# Altium schematic sheet Power.SchDoc
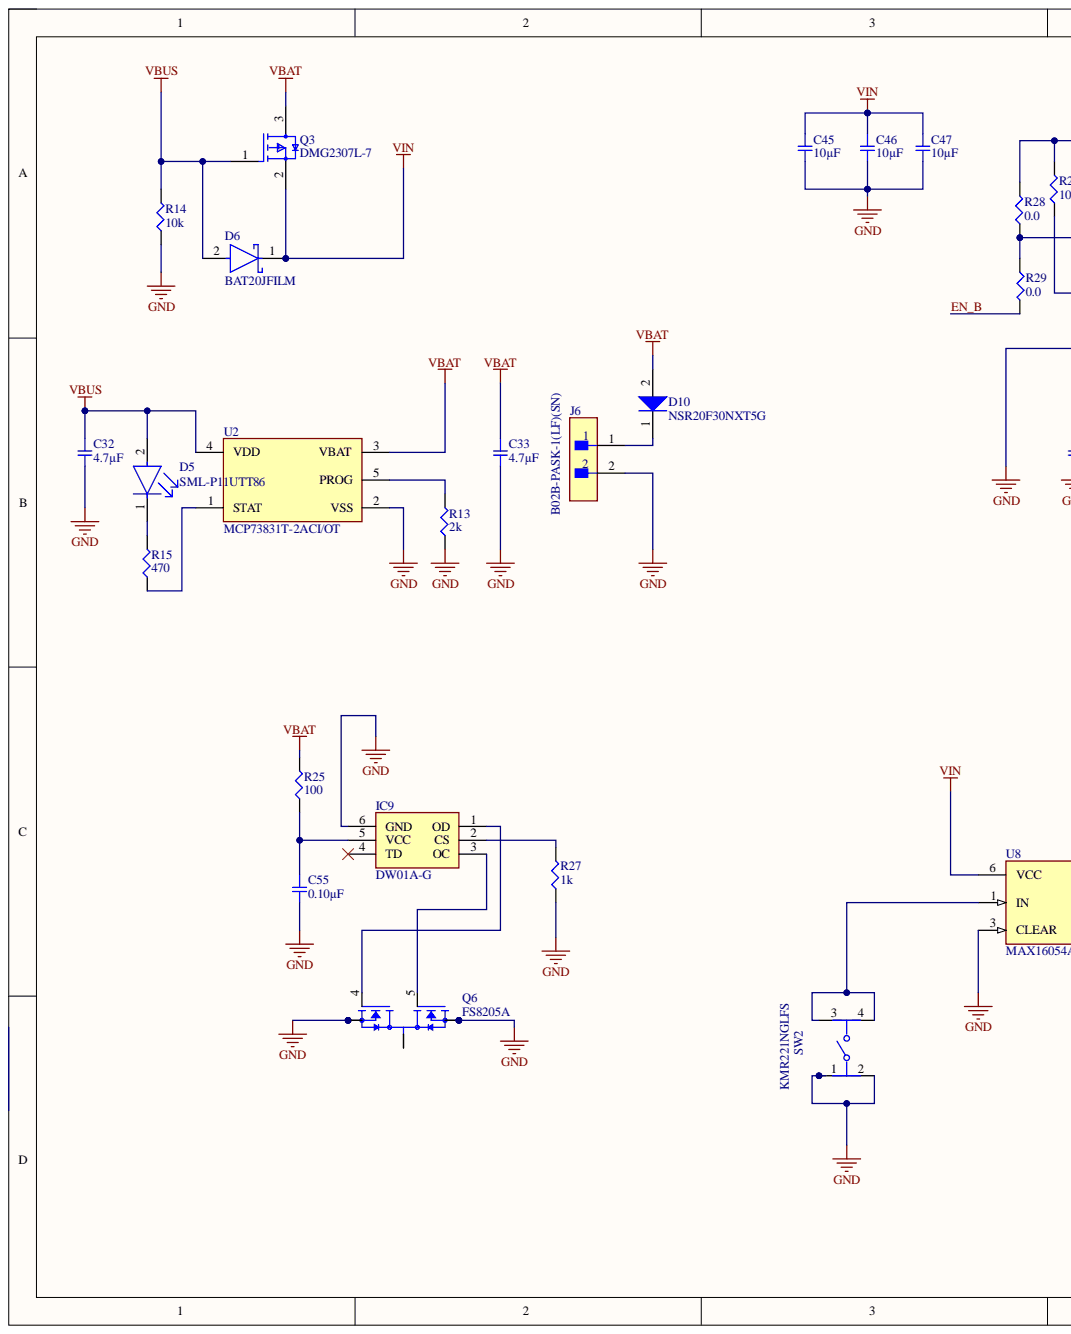
[diagram p0: Power.SchDoc, left]
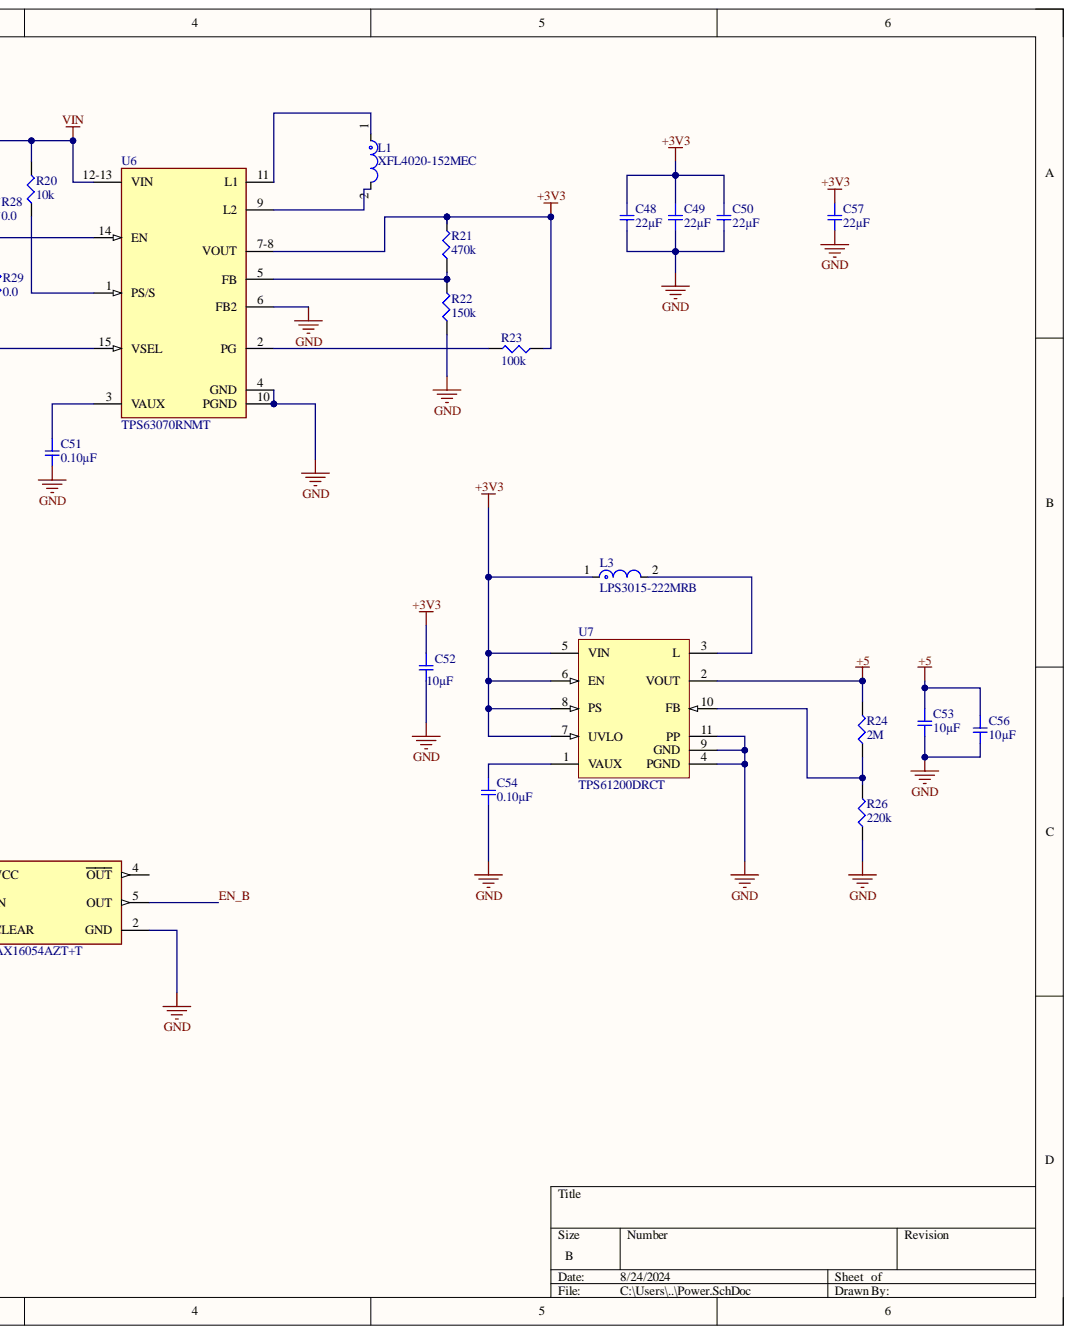
[diagram p1: Power.SchDoc, right]

## Parts
C32  4.7µF  [SMD-0402C]
C33  4.7µF  [SMD-0402C]
C45  10µF  [SMD-0805C]
C46  10µF  [SMD-0805C]
C47  10µF  [SMD-0603C]
C48  22µF  [SMD-0805C]
C49  22µF  [SMD-0805C]
C50  22µF  [SMD-0805C]
C51  0.10µF  [SMD-0402C]
C52  10µF  [SMD-0603C]
C53  10µF  [SMD-0603C]
C54  0.10µF  [SMD-0402C]
C55  0.10µF  [SMD-0402C]
C56  10µF  [SMD-0603C]
C57  22µF  [SMD-0603C]
D5  SML-P11UTT86  [FP-SML-P11UTT86-MFG]
D6  BAT20JFILM  [FP-BAT20JFILM-MFG]
D10  NSR20F30NXT5G  [ONSC-DSN-2-512AB-01_V]
IC9  DW01A-G  [SOT95P190X145-6N]
J6  B02B-PASK-1(LF)(SN)  [FP-B02B-PASK-1_LF_SN-MFG]
L1  XFL4020-152MEC  [FP-XFL4020-MFG]
L3  LPS3015-222MRB  [FP-LPS3015-MFG]
Q3  DMG2307L-7  [FP-SOT23-IPC_A]
Q6  FS8205A  [SOP65P640X120-8N]
R13  2k  [SMD-0402-RES]
R14  10k  [SMD-0402-RES]
R15  470  [SMD-0402-RES]
R20  10k  [SMD-0402-RES]
R21  470k  [SMD-0402-RES]
R22  150k  [SMD-0402-RES]
R23  100k  [SMD-0402-RES]
R24  2M  [SMD-0402-RES]
R25  100  [SMD-0402-RES]
R26  220k  [SMD-0402-RES]
R27  1k  [SMD-0402-RES]
R28  0.0  [SMD-0402-RES]
R29  0.0  [SMD-0402-RES]
SW2  KMR221NGLFS  [FP-KMR221NGLFS-0]
U2  MCP73831T-2ACI/OT  [FP-C04-091-OT-IPC_B]
U6  TPS63070RNMT  [FP-RNM0015A-MFG]
U7  TPS61200DRCT  [DRC0010J_V]
U8  MAX16054AZT+T  [FP-Z6-1-MFG]

## Nets
+3V3: C48.1 C49.1 C50.1 C52.1 C57.1 L3.1 R21.2 R23.1 U6.7-8(VOUT) U7.5(VIN) U7.6(EN) U7.7(UVLO) U7.8(PS)
+5: C53.1 C56.1 R24.2 U7.2(VOUT)
GND: C32.2 C33.2 C45.2 C46.2 C47.2 C48.2 C49.2 C50.2 C51.2 C52.2 C53.2 C54.2 C55.2 C56.2 C57.2 IC9.6(GND) J6.2 Q6.2 Q6.3 Q6.6 Q6.7 R13.1 R14.1 R22.1 R26.1 R27.1 SW2.1 SW2.2 U2.2(VSS) U6.4(GND) U6.6(FB2) U6.10(PGND) U6.15(VSEL) U7.4(PGND) U7.9(GND) U7.11(PP) U8.2(GND) U8.3(CLEAR)
VBAT: C33.1 D10.2 Q3.3 R25.2 U2.3(VBAT)
VBUS: C32.1 D5.2 D6.2 Q3.1 R14.2 U2.4(VDD)
VIN: C45.1 C46.1 C47.1 D6.1 Q3.2 R20.2 R28.2 U6.12-13(VIN) U8.6(VCC)
EN_B: R29.2 U8.5(OUT)
NetC51_1: C51.1 U6.3(VAUX)
NetC54_1: C54.1 U7.1(VAUX)
NetC55_1: C55.1 IC9.5(VCC) R25.1
NetD5_1: D5.1 R15.2
NetD10_1: D10.1 J6.1
NetIC9_1: IC9.1(OD) Q6.4
NetIC9_2: IC9.2(CS) R27.2
NetIC9_3: IC9.3(OC) Q6.5
NetL1_1: L1.1 U6.11(L1)
NetL1_2: L1.2 U6.9(L2)
NetL3_2: L3.2 U7.3(L)
NetQ6_1: Q6.1 Q6.8
NetR13_2: R13.2 U2.5(PROG)
NetR15_1: R15.1 U2.1(STAT)
NetR20_1: R20.1 U6.1(PS/S)
NetR21_1: R21.1 R22.2 U6.5(FB)
NetR23_2: R23.2 U6.2(PG)
NetR24_1: R24.1 R26.2 U7.10(FB)
NetR28_1: R28.1 R29.1 U6.14(EN)
NetSW2_3: SW2.3 SW2.4 U8.1(IN)

Not connected: IC9 4(TD); U8 4(OUT)

## Library data of the parts
C32 C33 = ZRB15XR61A475KE01D: CAP CER 4.7UF 10V X5R 0402; Manufacturer: Murata Electronics North America; @ qty: 0; Minimum Quantity: 1; Tolerance: ±10%; Unit Price (USD): 0.28; Applications: Acoustic Noise Reduction; Component Kind: Standard; Datasheets: http://search.murata.co.jp/Ceramy/image/img/A01X/G101/ENG/ZRB15XR61A475KE01-01.pdf; Digi-Key Part Number: 490-13252-1-ND; Factory Stock: 0; Features: Derating Recommended, Interposer Termination; Height - Seated (Max): -; Image: //media.digikey.com/Renders/Murata%20Renders/ZRB-SERIES-0402.jpg; Lead Spacing: -; Lead Style: -; Library Name: capacitor_murata_0402.IntLib; Mounting Type: Surface Mount, MLCC; Operating Temperature: -55°C ~ 85°C; Package / Case: 0402 (1005 Metric); Packaging: Cut Tape (CT); Part Number: ZRB15XR61A475KE01D; Part Status: Active; Quantity Available: 23076; Ratings: -; Series: ZRB; Size / Dimension: 0.039" L x 0.020" W (1.00mm x 0.50mm); Temperature Coefficient: X5R; Thickness (Max): 0.031" (0.80mm); Value: 4.7µF; Voltage: 10V
C45 C46 = GCJ21BR71A106KE01L: CAP CER 10UF 10V X7R 0805; Manufacturer: Murata Electronics North America; @ qty: 0; Minimum Quantity: 1; Tolerance: ±10%; Unit Price (USD): 0.56; Applications: Automotive, Boardflex Sensitive; Component Kind: Standard; Datasheets: http://www.murata.com/~/media/webrenewal/support/library/catalog/products/capacitor/mlcc/c03e.ashx; Digi-Key Part Number: 490-12646-1-ND; Factory Stock: 0; Features: Soft Termination; Height - Seated (Max): -; Image: //media.digikey.com/Renders/Murata%20Renders/0805%20(2012%20Metric)%20.85mm.jpg; Lead Spacing: -; Lead Style: -; Library Name: capacitor_murata_0805.IntLib; Mounting Type: Surface Mount, MLCC; Operating Temperature: -55°C ~ 125°C; Package / Case: 0805 (2012 Metric); Packaging: Cut Tape (CT); Part Number: GCJ21BR71A106KE01L; Part Status: Active; Quantity Available: 2702; Ratings: AEC-Q200; Series: GCJ; Size / Dimension: 0.079" L x 0.049" W (2.00mm x 1.25mm); Temperature Coefficient: X7R; Thickness (Max): 0.057" (1.45mm); Value: 10µF; Voltage: 10V
C47 = GRM188C81C106MA73D: CAP CER 10UF 16V X6S 0603; Manufacturer: Murata Electronics North America; @ qty: 0; Minimum Quantity: 1; Tolerance: ±20%; Unit Price (USD): 0.34; Applications: General Purpose; Component Kind: Standard; Datasheets: http://www.murata.com/~/media/webrenewal/support/library/catalog/products/capacitor/mlcc/c02e.pdf; Digi-Key Part Number: 490-7198-1-ND; Factory Stock: 0; Features: -; Height - Seated (Max): -; Image: //media.digikey.com/Renders/Murata%20Renders/ZRB-SERIES-0402.jpg; Lead Spacing: -; Lead Style: -; Library Name: capacitor_murata_0603.IntLib; Mounting Type: Surface Mount, MLCC; Operating Temperature: -55°C ~ 105°C; Package / Case: 0603 (1608 Metric); Packaging: Cut Tape (CT); Part Number: GRM188C81C106MA73D; Part Status: Active; Quantity Available: 215049; Ratings: -; Series: GRM; Size / Dimension: 0.063" L x 0.031" W (1.60mm x 0.80mm); Temperature Coefficient: X6S; Thickness (Max): 0.031" (0.80mm); Value: 10µF; Voltage: 16V
C48-C50 = GRM219R61A226MEA0D: CAP CER 22UF 10V X5R 0805; Manufacturer: Murata Electronics North America; @ qty: 0; Minimum Quantity: 1; Tolerance: ±20%; Unit Price (USD): 0.4; Applications: General Purpose; Component Kind: Standard; Datasheets: http://www.murata.com/~/media/webrenewal/support/library/catalog/products/capacitor/mlcc/c02e.pdf; Digi-Key Part Number: 490-9951-1-ND; Factory Stock: 0; Features: Derating Recommended; Height - Seated (Max): -; Image: //media.digikey.com/Renders/Murata%20Renders/0805%20(2012%20Metric)%20.85mm.jpg; Lead Spacing: -; Lead Style: -; Library Name: capacitor_murata_0805.IntLib; Mounting Type: Surface Mount, MLCC; Operating Temperature: -55°C ~ 85°C; Package / Case: 0805 (2012 Metric); Packaging: Cut Tape (CT); Part Number: GRM219R61A226MEA0D; Part Status: Active; Quantity Available: 179544; Ratings: -; Series: GRM; Size / Dimension: 0.079" L x 0.049" W (2.00mm x 1.25mm); Temperature Coefficient: X5R; Thickness (Max): 0.037" (0.95mm); Value: 22µF; Voltage: 10V
C51 C54 C55 = GCM155R71C104KA55D: CAP CER 0.1UF 16V X7R 0402; Manufacturer: Murata Electronics North America; @ qty: 0; Minimum Quantity: 1; Tolerance: ±10%; Unit Price (USD): 0.1; Applications: Automotive; Component Kind: Standard; Datasheets: http://www.murata.com/~/media/webrenewal/support/library/catalog/products/capacitor/mlcc/c03e.ashx; Digi-Key Part Number: 490-4759-1-ND; Factory Stock: 0; Features: -; Height - Seated (Max): -; Image: //media.digikey.com/Renders/Murata%20Renders/ZRB-SERIES-0402.jpg; Lead Spacing: -; Lead Style: -; Library Name: capacitor_murata_0402.IntLib; Mounting Type: Surface Mount, MLCC; Operating Temperature: -55°C ~ 125°C; Package / Case: 0402 (1005 Metric); Packaging: Cut Tape (CT); Part Number: GCM155R71C104KA55D; Part Status: Active; Quantity Available: 734194; Ratings: AEC-Q200; Series: GCM; Size / Dimension: 0.039" L x 0.020" W (1.00mm x 0.50mm); Temperature Coefficient: X7R; Thickness (Max): 0.022" (0.55mm); Value: 0.10µF; Voltage: 16V
C52 C53 C56 = GRM188R61C106MAALD: CAP CER 10UF 16V X5R 0603; Manufacturer: Murata Electronics North America; @ qty: 0; Minimum Quantity: 1; Tolerance: ±20%; Unit Price (USD): 0.34; Applications: General Purpose; Component Kind: Standard; Datasheets: http://www.murata.com/~/media/webrenewal/support/library/catalog/products/capacitor/mlcc/c02e.pdf; Digi-Key Part Number: 490-10729-1-ND; Factory Stock: 0; Features: -; Height - Seated (Max): -; Image: //media.digikey.com/Renders/Murata%20Renders/ZRB-SERIES-0402.jpg; Lead Spacing: -; Lead Style: -; Library Name: capacitor_murata_0603.IntLib; Mounting Type: Surface Mount, MLCC; Operating Temperature: -55°C ~ 85°C; Package / Case: 0603 (1608 Metric); Packaging: Cut Tape (CT); Part Number: GRM188R61C106MAALD; Part Status: Active; Quantity Available: 8571; Ratings: -; Series: GRM; Size / Dimension: 0.063" L x 0.031" W (1.60mm x 0.80mm); Temperature Coefficient: X5R; Thickness (Max): 0.037" (0.95mm); Value: 10µF; Voltage: 16V
C57 = GRM187R61A226ME15D: CAP CER 22UF 10V X5R 0603; Manufacturer: Murata Electronics North America; @ qty: 0; Minimum Quantity: 1; Tolerance: ±20%; Unit Price (USD): 0.54; Applications: General Purpose; Component Kind: Standard; Datasheets: http://psearch.en.murata.com/capacitor/product/GRM187R61A226ME15%23.pdf; Digi-Key Part Number: 490-13243-1-ND; Factory Stock: 0; Features: Derating Recommended; Height - Seated (Max): -; Image: //media.digikey.com/Renders/Murata%20Renders/ZRB-SERIES-0402.jpg; Lead Spacing: -; Lead Style: -; Library Name: capacitor_murata_0603.IntLib; Mounting Type: Surface Mount, MLCC; Operating Temperature: -55°C ~ 85°C; Package / Case: 0603 (1608 Metric); Packaging: Cut Tape (CT); Part Number: GRM187R61A226ME15D; Part Status: Active; Quantity Available: 10253; Ratings: -; Series: GRM; Size / Dimension: 0.063" L x 0.031" W (1.60mm x 0.80mm); Temperature Coefficient: X5R; Thickness (Max): 0.031" (0.80mm); Value: 22µF; Voltage: 10V
D5 = CMP-08952-000028-1: LED RED CLEAR 1006 SMD; Dominant Wavelength: 621nm; Forward Current: 20mA; Forward Voltage: 1.8V; Lens Color: Colorless; Lens Style: Square; Luminous Intensity: 5.5mcd; Number of LEDs: 1; Test Current: 1mA; Viewing Angle: 150°; Case Code (Imperial): 0402; Case Code (Metric): 1005; Case/Package: 0402; Color: Red; Component Kind: Standard; Height: 0.25mm; Illumination Color: Red; Lead Free: Lead Free; Length: 1mm; Lens Transparency: Clear; Library Name: Altium Content Vault; Max Operating Temperature: 85°C; Min Operating Temperature: -40°C; Mount: Surface Mount; Number of Pins: 2; Package Quantity: 1; Packaging: Tape and Reel; Radiation Hardening: No; REACH SVHC: No SVHC; RoHS Compliant: Yes; Series: PicoLED™; Wavelength: 621nm; Width: 0.6mm
D6 = CMP-12187-000030-1: DIODE SCHOTTKY 23V 1A SOD323; Capacitance: 30pF; Element Configuration: Single; Forward Voltage: 620mV; Max Forward Surge Current (Ifsm): 5A; Max Reverse Leakage Current: 12uA; Polarity: Standard; Average Rectified Current: 1A; Component Kind: Standard; Current Rating: 1A; Forward Current: 1A; Height: 1.03mm; Lead Free: Lead Free; Length: 1.8mm; Library Name: Altium Content Vault; Max Operating Temperature: 150°C; Max Repetitive Reverse Voltage (Vrrm): 23V; Max Reverse Voltage (DC): 23V; Max Surge Current: 5A; Min Operating Temperature: -65°C; Mount: Surface Mount; Number of Pins: 2; Output Current: 1A; Package Quantity: 1; Packaging: Tape and Reel; Peak Non-Repetitive Surge Current: 5A; Peak Reverse Current: 12uA; Radiation Hardening: No; REACH SVHC: No SVHC; Voltage Rating (DC): 23V; Weight: 0.01lb; Width: 1.35mm
D10 = CMP-1055-00233-1: Schottky Barrier Diode, 2-Pin DSN, Pb-Free, Tape and Reel; Manufacturer: On Semiconductor; Configuration: Single; IFSM Max (A): 28; Component Kind: Standard; ComponentLink1Description: Manufacturer URL; ComponentLink1URL: http://www.onsemi.com/; ComponentLink2Description: Datasheet; ComponentLink2URL: http://www.onsemi.com/pub_link/Collateral/NSR20F30-D.PDF; ComponentLink3Description: Package Specification; ComponentLink3URL: http://www.onsemi.cn/pub_link/Collateral/152AB.PDF; DatasheetVersion: Rev. 3; Library Name: Altium Content Vault; Mounting Technology: Surface Mount; PackageDescription: 2-Pin Micro Molded Package, Body 1.6 x 0.8 mm; PackageReference: DSN-2-512AB-01; PackageVersion: Rev. B, 01/2011; Packing: Tape and Reel; PartNumber: NSR20F30NXT5G; RoHS: TRUE
IC9 = DW01A-G: Integrated Circuit; Manufacturer_Name: SII Semiconductor; Component Kind: Standard; Datasheet Link: http://www.ic-fortune.com/upload/Download/DW01x-DS-17_EN.pdf; Height: 1.45mm; Manufacturer_Part_Number: DW01A-G
J6 = CMP-17439-000415-1: CONN HEADER VERT 2POS 2MM; Current Rating: 3A; Gender: Male; Insulation Resistance: 1GR; Mated Stacking Heights: 14.9mm; Number of Contacts: 2; Voltage Rating: 250V; Color: Natural; Component Kind: Standard; Connector Type: Header; Contact Finish - Mating: TIN; Contact Material: Copper Alloy; Contact Mating Length: 0.126inch; Contact Plating: Tin; Contact Shape: Square; Contact Type: Male Pin; Fastening Type: Ramp; Flammability Rating: UL94 V-0; Housing Color: White; Insulation Color: Natural; Insulation Material: PBT; Lead Free: Lead Free; Library Name: Altium Content Vault; Material: Polybutylene; Max Operating Temperature: 85°C; Min Operating Temperature: -25°C; Mount: Through Hole; Number of Positions: 2; Number of Rows: 1; Orientation: Straight; Packaging: Bulk; Pitch: 2mm; Series: PA; Termination: Solder
L1 = CMP-11268-000104-1: Inductor Power Shielded Wirewound 1.5uH 20% 100KHz 9.1A 15.8mOhm DCR 1515 Automotive Embossed Plastic T/R; Core Material: Composite; DC Resistance (DCR): 15.8mR; Saturation Current: 4.1A; Self Resonant Frequency: 59MHz; Case/Package: SMD/SMT; Component Kind: Standard; Height: 2.1mm; Inductance: 1.5uH; Inductance Tolerance: 20%; Lead Free: Contains Lead; Length: 4.3mm; Library Name: Altium Content Vault; Max DC Current: 9.1A; Max Operating Temperature: 125°C; Min Operating Temperature: -40°C; Mount: Surface Mount; Packaging: Tape and Reel; REACH SVHC: No SVHC; RMS Current (Irms): 9.1A; RoHS Compliant: Yes; Series: XFL4020; Shielding: Shielded; Termination: SMD/SMT; Test Frequency: 100kHz; Tolerance: 20%; Width: 4.3mm
L3 = CMP-2000-06574-2: Fixed Inductors LPS3015 AEC-Q200 2.2uH 1.4A 20% SMD; Core Material: Ferrite; DC Resistance (DCR): 110mR; Max DC Current: 2.1A; RMS Current (Irms): 1.1A; Saturation Current: 2A; Self Resonant Frequency: 110MHz; Shielding: Shielded; Test Frequency: 100kHz; Component Kind: Standard; Height: 1.4mm; Inductance: 2.2uH; Inductance Tolerance: 20%; Length: 3mm; Library Name: Altium Content Vault; Max Operating Temperature: 85°C; Min Operating Temperature: -40°C; Package Quantity: 1; Packaging: Cut Tape; REACH SVHC: No SVHC; Termination: SMD/SMT; Tolerance: 20%; Weight: 0.001411oz; Width: 3mm
Q3 = CMP-12801-000080-1: MOSFET P-CH 30V 2.5A SOT-23; Continuous Drain Current (ID): 3.8A; Drain to Source Resistance: 90mR; Element Configuration: Single; Fall Time: 13.4ns; Gate to Source Voltage (Vgs): 20V; Input Capacitance: 371.3pF; Max Power Dissipation: 760mW; Number of Channels: 1; Power Dissipation: 1.36W; Rise Time: 7.3ns; Turn-Off Delay Time: 22.4ns; Turn-On Delay Time: 4.8ns; Case/Package: SOT-23; Component Kind: Standard; Drain to Source Voltage (Vdss): 30V; Height: 1mm; Lead Free: Lead Free; Length: 3mm; Library Name: Altium Content Vault; Max Operating Temperature: 150°C; Min Operating Temperature: -55°C; Mount: Surface Mount; Number of Elements: 1; Number of Pins: 3; Package Quantity: 3000; Packaging: Tape and Reel; Radiation Hardening: No; Rds On Max: 90mR; REACH SVHC: No SVHC; Weight: 0.000282oz; Width: 1.4mm
Q6 = FS8205A: N/A; MF: Fortune Semiconductor; Availability: Not in stock; Check_prices: https://www.snapeda.com/parts/FS8205A/Fortune+Semiconductor/view-part/?ref=eda; Component Kind: Standard; Library Name: FS8205A.IntLib; MP: FS8205A; Package: Package; Price: None; SnapEDA_Link: https://www.snapeda.com/parts/FS8205A/Fortune+Semiconductor/view-part/?ref=snap
R13 = ERJ-2GEJ202X: RES SMD 2K OHM 5% 1/10W 0402; Manufacturer: Panasonic Electronic Components; @ qty: 1500; Factory Stock: 0; Minimum Quantity: 1; Power (Watts): 0.1W, 1/10W; Temperature Coefficient: ±200ppm/°C; Unit Price (USD): 0.00350; Component Kind: Standard; Composition: Thick Film; Datasheets: http://industrial.panasonic.com/www-cgi/jvcr13pz.cgi?E+PZ+3+AOA0001+ERJ2GEJ202X+7+WW; Digi-Key Part Number: P2.0KJCT-ND; Failure Rate: -; Features: Automotive AEC-Q200; Height - Seated (Max): 0.016" (0.40mm); Image: //media.digikey.com/Photos/Panasonic%20Photos/ERJ-PA2-Series.jpg; Library Name: Panasonic_ERJ_0402.IntLib; Number of Terminations: 2; Operating Temperature: -55°C ~ 155°C; Package / Case: 0402 (1005 Metric); Packaging: Cut Tape (CT); Part Number: ERJ-2GEJ202X; Part Status: Active; Quantity Available: 185761; Series: ERJ; Size / Dimension: 0.039" L x 0.020" W (1.00mm x 0.50mm); Supplier Device Package: 0402; Tolerance: ±5%; Value: 2k
R14 R20 = ERJ-2GEJ103X: RES SMD 10K OHM 5% 1/10W 0402; Manufacturer: Panasonic Electronic Components; @ qty: 1500; Factory Stock: 0; Minimum Quantity: 1; Power (Watts): 0.1W, 1/10W; Temperature Coefficient: ±200ppm/°C; Unit Price (USD): 0.00350; Component Kind: Standard; Composition: Thick Film; Datasheets: http://industrial.panasonic.com/www-cgi/jvcr13pz.cgi?E+PZ+3+AOA0001+ERJ2GEJ103X+7+WW; Digi-Key Part Number: P10KJCT-ND; Failure Rate: -; Features: Automotive AEC-Q200; Height - Seated (Max): 0.016" (0.40mm); Image: //media.digikey.com/Photos/Panasonic%20Photos/ERJ-PA2-Series.jpg; Library Name: Panasonic_ERJ_0402.IntLib; Number of Terminations: 2; Operating Temperature: -55°C ~ 155°C; Package / Case: 0402 (1005 Metric); Packaging: Cut Tape (CT); Part Number: ERJ-2GEJ103X; Part Status: Active; Quantity Available: 2560215; Series: ERJ; Size / Dimension: 0.039" L x 0.020" W (1.00mm x 0.50mm); Supplier Device Package: 0402; Tolerance: ±5%; Value: 10k
R15 = ERJ-2RKF4700X: RES SMD 470 OHM 1% 1/10W 0402; Manufacturer: Panasonic Electronic Components; @ qty: 1500; Factory Stock: 0; Minimum Quantity: 1; Power (Watts): 0.1W, 1/10W; Temperature Coefficient: ±100ppm/°C; Unit Price (USD): 0.00496; Component Kind: Standard; Composition: Thick Film; Datasheets: http://industrial.panasonic.com/www-cgi/jvcr13pz.cgi?E+PZ+3+AOA0002+ERJ2RKF4700X+7+WW; Digi-Key Part Number: P470LCT-ND; Failure Rate: -; Features: Automotive AEC-Q200; Height - Seated (Max): 0.016" (0.40mm); Image: //media.digikey.com/Photos/Panasonic%20Photos/ERJ-PA2-Series.jpg; Library Name: Panasonic_ERJ_0402.IntLib; Number of Terminations: 2; Operating Temperature: -55°C ~ 155°C; Package / Case: 0402 (1005 Metric); Packaging: Cut Tape (CT); Part Number: ERJ-2RKF4700X; Part Status: Active; Quantity Available: 52644; Series: ERJ; Size / Dimension: 0.039" L x 0.020" W (1.00mm x 0.50mm); Supplier Device Package: 0402; Tolerance: ±1%; Value: 470
R21 = ERJ-2RKF4703X: RES SMD 470K OHM 1% 1/10W 0402; Manufacturer: Panasonic Electronic Components; @ qty: 1500; Factory Stock: 0; Minimum Quantity: 1; Power (Watts): 0.1W, 1/10W; Temperature Coefficient: ±100ppm/°C; Unit Price (USD): 0.00496; Component Kind: Standard; Composition: Thick Film; Datasheets: http://industrial.panasonic.com/www-cgi/jvcr13pz.cgi?E+PZ+3+AOA0002+ERJ2RKF4703X+7+WW; Digi-Key Part Number: P470KLCT-ND; Failure Rate: -; Features: Automotive AEC-Q200; Height - Seated (Max): 0.016" (0.40mm); Image: //media.digikey.com/Photos/Panasonic%20Photos/ERJ-PA2-Series.jpg; Library Name: Panasonic_ERJ_0402.IntLib; Number of Terminations: 2; Operating Temperature: -55°C ~ 155°C; Package / Case: 0402 (1005 Metric); Packaging: Cut Tape (CT); Part Number: ERJ-2RKF4703X; Part Status: Active; Quantity Available: 171557; Series: ERJ; Size / Dimension: 0.039" L x 0.020" W (1.00mm x 0.50mm); Supplier Device Package: 0402; Tolerance: ±1%; Value: 470k
R22 = ERJ-2GEJ154X: RES SMD 150K OHM 5% 1/10W 0402; Manufacturer: Panasonic Electronic Components; @ qty: 1500; Factory Stock: 0; Minimum Quantity: 1; Power (Watts): 0.1W, 1/10W; Temperature Coefficient: ±200ppm/°C; Unit Price (USD): 0.00350; Component Kind: Standard; Composition: Thick Film; Datasheets: http://industrial.panasonic.com/www-cgi/jvcr13pz.cgi?E+PZ+3+AOA0001+ERJ2GEJ154X+7+WW; Digi-Key Part Number: P150KJCT-ND; Failure Rate: -; Features: Automotive AEC-Q200; Height - Seated (Max): 0.016" (0.40mm); Image: //media.digikey.com/Photos/Panasonic%20Photos/ERJ-PA2-Series.jpg; Library Name: Panasonic_ERJ_0402.IntLib; Number of Terminations: 2; Operating Temperature: -55°C ~ 155°C; Package / Case: 0402 (1005 Metric); Packaging: Cut Tape (CT); Part Number: ERJ-2GEJ154X; Part Status: Active; Quantity Available: 100107; Series: ERJ; Size / Dimension: 0.039" L x 0.020" W (1.00mm x 0.50mm); Supplier Device Package: 0402; Tolerance: ±5%; Value: 150k
R23 = ERJ-2GEJ104X: RES SMD 100K OHM 5% 1/10W 0402; Manufacturer: Panasonic Electronic Components; @ qty: 1500; Factory Stock: 0; Minimum Quantity: 1; Power (Watts): 0.1W, 1/10W; Temperature Coefficient: ±200ppm/°C; Unit Price (USD): 0.00350; Component Kind: Standard; Composition: Thick Film; Datasheets: http://industrial.panasonic.com/www-cgi/jvcr13pz.cgi?E+PZ+3+AOA0001+ERJ2GEJ104X+7+WW; Digi-Key Part Number: P100KJCT-ND; Failure Rate: -; Features: Automotive AEC-Q200; Height - Seated (Max): 0.016" (0.40mm); Image: //media.digikey.com/Photos/Panasonic%20Photos/ERJ-PA2-Series.jpg; Library Name: Panasonic_ERJ_0402.IntLib; Number of Terminations: 2; Operating Temperature: -55°C ~ 155°C; Package / Case: 0402 (1005 Metric); Packaging: Cut Tape (CT); Part Number: ERJ-2GEJ104X; Part Status: Active; Quantity Available: 2387986; Series: ERJ; Size / Dimension: 0.039" L x 0.020" W (1.00mm x 0.50mm); Supplier Device Package: 0402; Tolerance: ±5%; Value: 100k
R24 = ERJ-2GEJ205X: RES SMD 2M OHM 5% 1/10W 0402; Manufacturer: Panasonic Electronic Components; @ qty: 1500; Factory Stock: 0; Minimum Quantity: 1; Power (Watts): 0.1W, 1/10W; Temperature Coefficient: -400/ +150ppm/°C; Unit Price (USD): 0.00350; Component Kind: Standard; Composition: Thick Film; Datasheets: http://industrial.panasonic.com/www-cgi/jvcr13pz.cgi?E+PZ+3+AOA0001+ERJ2GEJ205X+7+WW; Digi-Key Part Number: P2.0MJCT-ND; Failure Rate: -; Features: Automotive AEC-Q200; Height - Seated (Max): 0.016" (0.40mm); Image: //media.digikey.com/Photos/Panasonic%20Photos/ERJ-PA2-Series.jpg; Library Name: Panasonic_ERJ_0402.IntLib; Number of Terminations: 2; Operating Temperature: -55°C ~ 155°C; Package / Case: 0402 (1005 Metric); Packaging: Cut Tape (CT); Part Number: ERJ-2GEJ205X; Part Status: Active; Quantity Available: 395013; Series: ERJ; Size / Dimension: 0.039" L x 0.020" W (1.00mm x 0.50mm); Supplier Device Package: 0402; Tolerance: ±5%; Value: 2M
R25 = ERJ-2RKF1000X: RES SMD 100 OHM 1% 1/10W 0402; Manufacturer: Panasonic Electronic Components; @ qty: 1500; Factory Stock: 0; Minimum Quantity: 1; Power (Watts): 0.1W, 1/10W; Temperature Coefficient: ±100ppm/°C; Unit Price (USD): 0.00496; Component Kind: Standard; Composition: Thick Film; Datasheets: http://industrial.panasonic.com/www-cgi/jvcr13pz.cgi?E+PZ+3+AOA0002+ERJ2RKF1000X+7+WW; Digi-Key Part Number: P100LCT-ND; Failure Rate: -; Features: Automotive AEC-Q200; Height - Seated (Max): 0.016" (0.40mm); Image: //media.digikey.com/Photos/Panasonic%20Photos/ERJ-PA2-Series.jpg; Library Name: Panasonic_ERJ_0402.IntLib; Number of Terminations: 2; Operating Temperature: -55°C ~ 155°C; Package / Case: 0402 (1005 Metric); Packaging: Cut Tape (CT); Part Number: ERJ-2RKF1000X; Part Status: Active; Quantity Available: 2283953; Series: ERJ; Size / Dimension: 0.039" L x 0.020" W (1.00mm x 0.50mm); Supplier Device Package: 0402; Tolerance: ±1%; Value: 100
R26 = ERJ-2GEJ224X: RES SMD 220K OHM 5% 1/10W 0402; Manufacturer: Panasonic Electronic Components; @ qty: 1500; Factory Stock: 0; Minimum Quantity: 1; Power (Watts): 0.1W, 1/10W; Temperature Coefficient: ±200ppm/°C; Unit Price (USD): 0.00350; Component Kind: Standard; Composition: Thick Film; Datasheets: http://industrial.panasonic.com/www-cgi/jvcr13pz.cgi?E+PZ+3+AOA0001+ERJ2GEJ224X+7+WW; Digi-Key Part Number: P220KJCT-ND; Failure Rate: -; Features: Automotive AEC-Q200; Height - Seated (Max): 0.016" (0.40mm); Image: //media.digikey.com/Photos/Panasonic%20Photos/ERJ-PA2-Series.jpg; Library Name: Panasonic_ERJ_0402.IntLib; Number of Terminations: 2; Operating Temperature: -55°C ~ 155°C; Package / Case: 0402 (1005 Metric); Packaging: Cut Tape (CT); Part Number: ERJ-2GEJ224X; Part Status: Active; Quantity Available: 84801; Series: ERJ; Size / Dimension: 0.039" L x 0.020" W (1.00mm x 0.50mm); Supplier Device Package: 0402; Tolerance: ±5%; Value: 220k
R27 = ERJ-2GEJ102X: RES SMD 1K OHM 5% 1/10W 0402; Manufacturer: Panasonic Electronic Components; @ qty: 1500; Factory Stock: 0; Minimum Quantity: 1; Power (Watts): 0.1W, 1/10W; Temperature Coefficient: ±200ppm/°C; Unit Price (USD): 0.00350; Component Kind: Standard; Composition: Thick Film; Datasheets: http://industrial.panasonic.com/www-cgi/jvcr13pz.cgi?E+PZ+3+AOA0001+ERJ2GEJ102X+7+WW; Digi-Key Part Number: P1.0KJCT-ND; Failure Rate: -; Features: Automotive AEC-Q200; Height - Seated (Max): 0.016" (0.40mm); Image: //media.digikey.com/Photos/Panasonic%20Photos/ERJ-PA2-Series.jpg; Library Name: Panasonic_ERJ_0402.IntLib; Number of Terminations: 2; Operating Temperature: -55°C ~ 155°C; Package / Case: 0402 (1005 Metric); Packaging: Cut Tape (CT); Part Number: ERJ-2GEJ102X; Part Status: Active; Quantity Available: 1939857; Series: ERJ; Size / Dimension: 0.039" L x 0.020" W (1.00mm x 0.50mm); Supplier Device Package: 0402; Tolerance: ±5%; Value: 1k
R28 R29 = ERJ-2GE0R00X: RES SMD 0.0OHM JUMPER 1/10W 0402; Manufacturer: Panasonic Electronic Components; @ qty: 1500; Factory Stock: 0; Minimum Quantity: 1; Power (Watts): 0.1W, 1/10W; Unit Price (USD): 0.00384; Component Kind: Standard; Composition: Thick Film; Datasheets: http://industrial.panasonic.com/www-cgi/jvcr13pz.cgi?E+PZ+3+AOA0001+ERJ2GE0R00X+7+WW; Digi-Key Part Number: P0.0JCT-ND; Failure Rate: -; Features: Automotive AEC-Q200; Height - Seated (Max): 0.016" (0.40mm); Image: //media.digikey.com/Photos/Panasonic%20Photos/ERJ-PA2-Series.jpg; Library Name: Panasonic_ERJ_0402.IntLib; Number of Terminations: 2; Operating Temperature: -55°C ~ 155°C; Package / Case: 0402 (1005 Metric); Packaging: Cut Tape (CT); Part Number: ERJ-2GE0R00X; Part Status: Active; Quantity Available: 3152483; Series: ERJ; Size / Dimension: 0.039" L x 0.020" W (1.00mm x 0.50mm); Supplier Device Package: 0402; Temperature Coefficient: -; Tolerance: Jumper; Value: 0.0
SW2 = CMP-10040-000006-1: SWITCH TACTILE SPST-NO 0.05A 32V; Manufacturer: C&K; Contact Current Rating: 50mA; Contact Resistance: 100mΩ; Electromechanical Life: 200000Cycles; Operating Force: 2N; Automotive: No; Category: Switch; Component Kind: Standard; ComponentLink2Description: Datasheet; ComponentLink2URL: https://dznh3ojzb2azq.cloudfront.net/products/Tactile/KMR2/documents/datasheet.pdf; Device Class L1: Electromechanical; Device Class L2: Switches; Device Class L3: Tactile Switches; Digikey Description: SWITCH TACTILE SPST-NO 0.05A 32V; Height: 1.9mm; Lead Free: Yes; Library Name: Altium Content Vault; Manufacturer Part Number: KMR221NGLFS; Mount: Surface Mount; Mouser Description: Tactile Switches Tact; Octopart: https://octopart.com/kmr221nglfs-c%26k+components-7203802; Package: 0; RoHS: TRUE; Temperature: -40°C to +85°C; Temperature Range High: +85°C; Temperature Range Low: -40°C; Throw Configuration: SPST-NO; Voltage Rating Dc: 32V
U2 = CMP-0133-00143-2: IC CONTROLLR LI-ION 4.2V SOT23-5; Drain to Source Resistance: 350mR; Max Charge Current: 500mA; Max Input Voltage: 6V; Max Output Voltage: 4.5V; Max Power Dissipation: 1.54W; Min Output Voltage: 4.168V; Min Supply Voltage: 3.75V; Number of Cells: 1; Voltage Rating: 4.534V; Case/Package: SOT-23; Component Kind: Standard; Contact Plating: Tin; Height: 0.051inch; Lead Free: Lead Free; Length: 0.122inch; Library Name: Altium Content Vault; Max Operating Temperature: 85°C; Max Supply Voltage: 6V; Min Operating Temperature: -40°C; Mount: Surface Mount; Number of Channels: 1; Number of Outputs: 1; Number of Pins: 5; Output Current: 500mA; Output Voltage: 4.2V; Packaging: Tape and Reel; Radiation Hardening: No; REACH SVHC: No SVHC; Termination: SMD/SMT; Weight: 0.00709oz; Width: 0.071inch
U6 = CMP-04918-000001-1: IC REG BCK BST ADJ 2A 15VQFN; Manufacturer: Texas Instruments; Max Duty Cycle: 100%; Max Junction Temp: +125°C; Max Supply Voltage: 16V; Min Supply Voltage: 2V; Nominal Supply Current: 5-54uA; Number Of Outputs: 1; Output Type: AdjustableProgrammable; Output Voltage: 2.5-9V; Standoff Height: 0mm; Switching Frequency: 2400kHz; Switching Topology: Buck-Boost; Automotive: No; Category: IC; Component Kind: Standard; ComponentLink2Description: Datasheet; ComponentLink2URL: http://www.ti.com/lit/ds/symlink/tps63070.pdf; Device Class L1: Integrated Circuits (ICs); Device Class L2: Power Management ICs; Device Class L3: Voltage Regulators - Switching; Digikey Description: IC REG BCK BST ADJ 2A 15VQFN; Height: 1mm; Lead Free: Yes; Library Name: Altium Content Vault; Manufacturer Part Number: TPS63070RNMT; Octopart: https://octopart.com/tps63070rnmt-texas+instruments-75117739; Output Current: 2A; Package: RNM0015A; RoHS: TRUE; Temperature: -40°C to +125°C; Temperature Range High: +125°C; Temperature Range Low: -40°C
U7 = CMP-0347-00023-2: Boost Voltage Converter with 0.3 to 5.5 V Input and 1.8 to 5.5 V Output, -40 to 85 degC, 10-Pin SON (DRC), Green (RoHS & no Sb/Br); Manufacturer: Texas Instruments; Regulated Outputs(#): 1; SubFamily: Step-Up Regulator; Switching Frequency(Max)(kHz): 1250; Synchronous Rectification: TRUE; Vin(Max)(V): 5.5; Vin(Min)(V): 0.3; Vout(Min)(V): 1.8; Component Kind: Standard; ComponentLink1Description: Datasheet; ComponentLink1URL: http://www.ti.com/general/docs/lit/getliterature.tsp?genericPartNumber=TPS61200&fileType=pdf; ComponentLink2Description: Package Specification; ComponentLink2URL: http://www.ti.com/litv/pdf/mpds117k; DatasheetVersion: SLVS577B; Eco-Plan: Green (RoHS & no Sb/Br); Library Name: Altium Content Vault; Mounting Technology: Surface Mount; PackageDescription: 10-Pin Plastic Small Outline No-Lead, 3 x 3 x 1 mm Body, 0.5 mm Pitch, Exposed Pad; PackageReference: DRC0010J; PackageVersion: revL, Sep-2011; PartNumber: TPS61200DRCT; Topology: Boost; Vout(Max)(V): 5.5
U8 = CMP-0251-00627-2: IC INTFACE SPECIALIZED TSOT23-6; Max Power Dissipation: 727W; Max Supply Voltage: 5.5V; Min Supply Voltage: 2.7V; Number of Voltages Monitored: 1; Operating Supply Current: 7uA; Output Type: PUSH-PULL; Undervoltage Threshold: 1.8V; Watchdog Timer: No; Case/Package: SOT-23-6; Component Kind: Standard; Contact Plating: Tin; Height: 0.73mm; Length: 4mm; Library Name: Altium Content Vault; Max Operating Temperature: 125°C; Min Operating Temperature: -40°C; Mount: Surface Mount; Number of Pins: 6; Package Quantity: 1; Packaging: Tape and Reel; Radiation Hardening: No; RoHS Compliant: Yes; Series: -; Width: 4mm
